# Altium schematic sheet 18-USB_HS.SchDoc
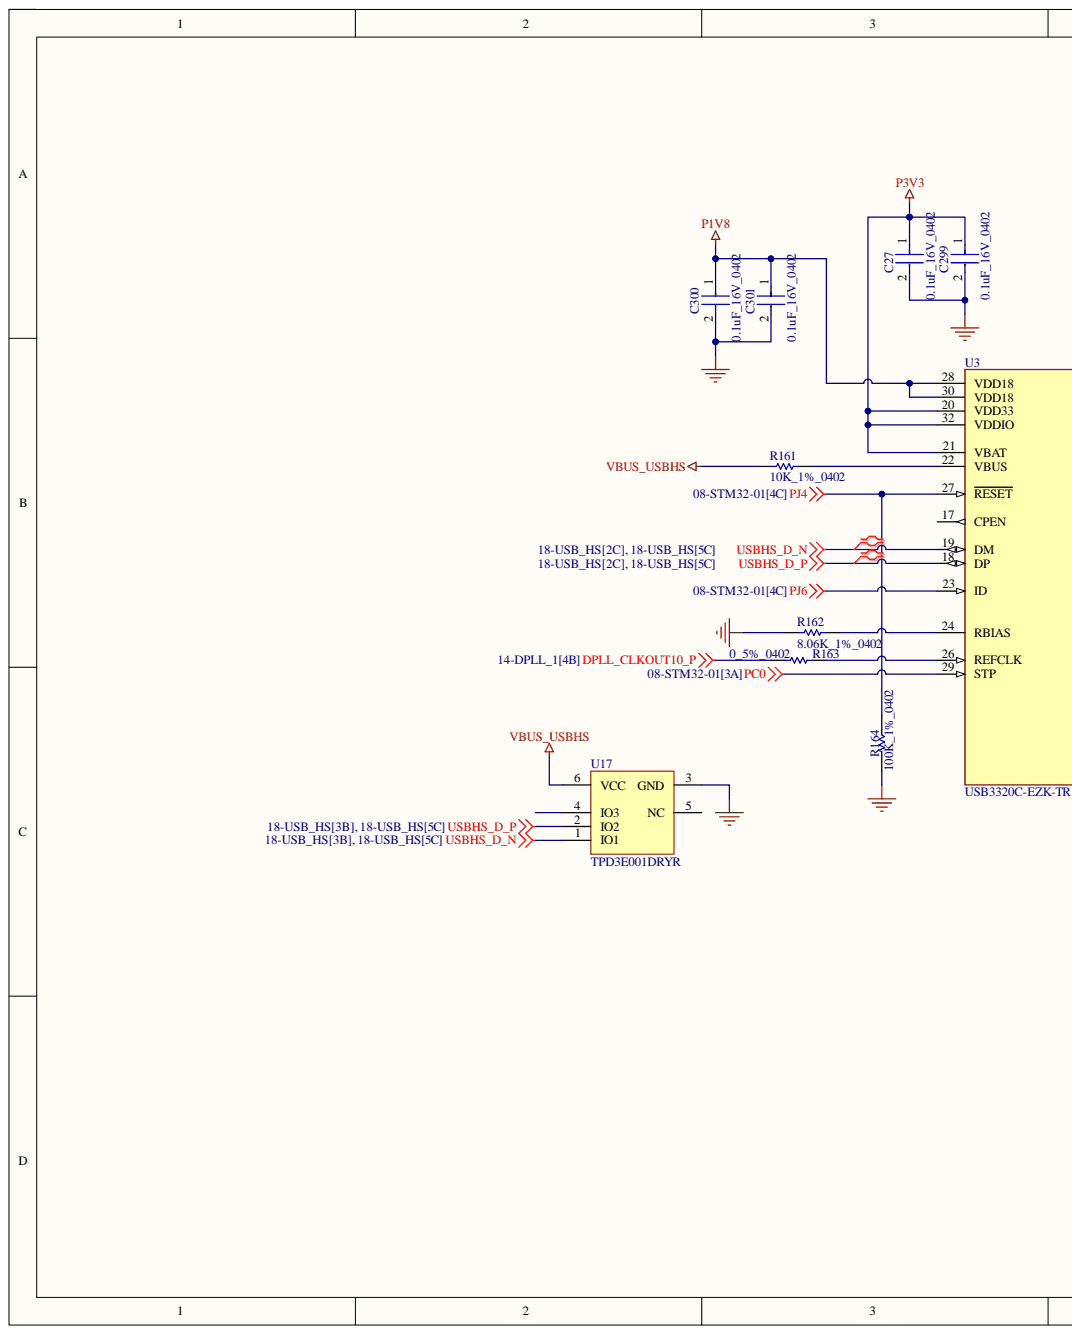
[diagram p0: 18-USB_HS.SchDoc, left]
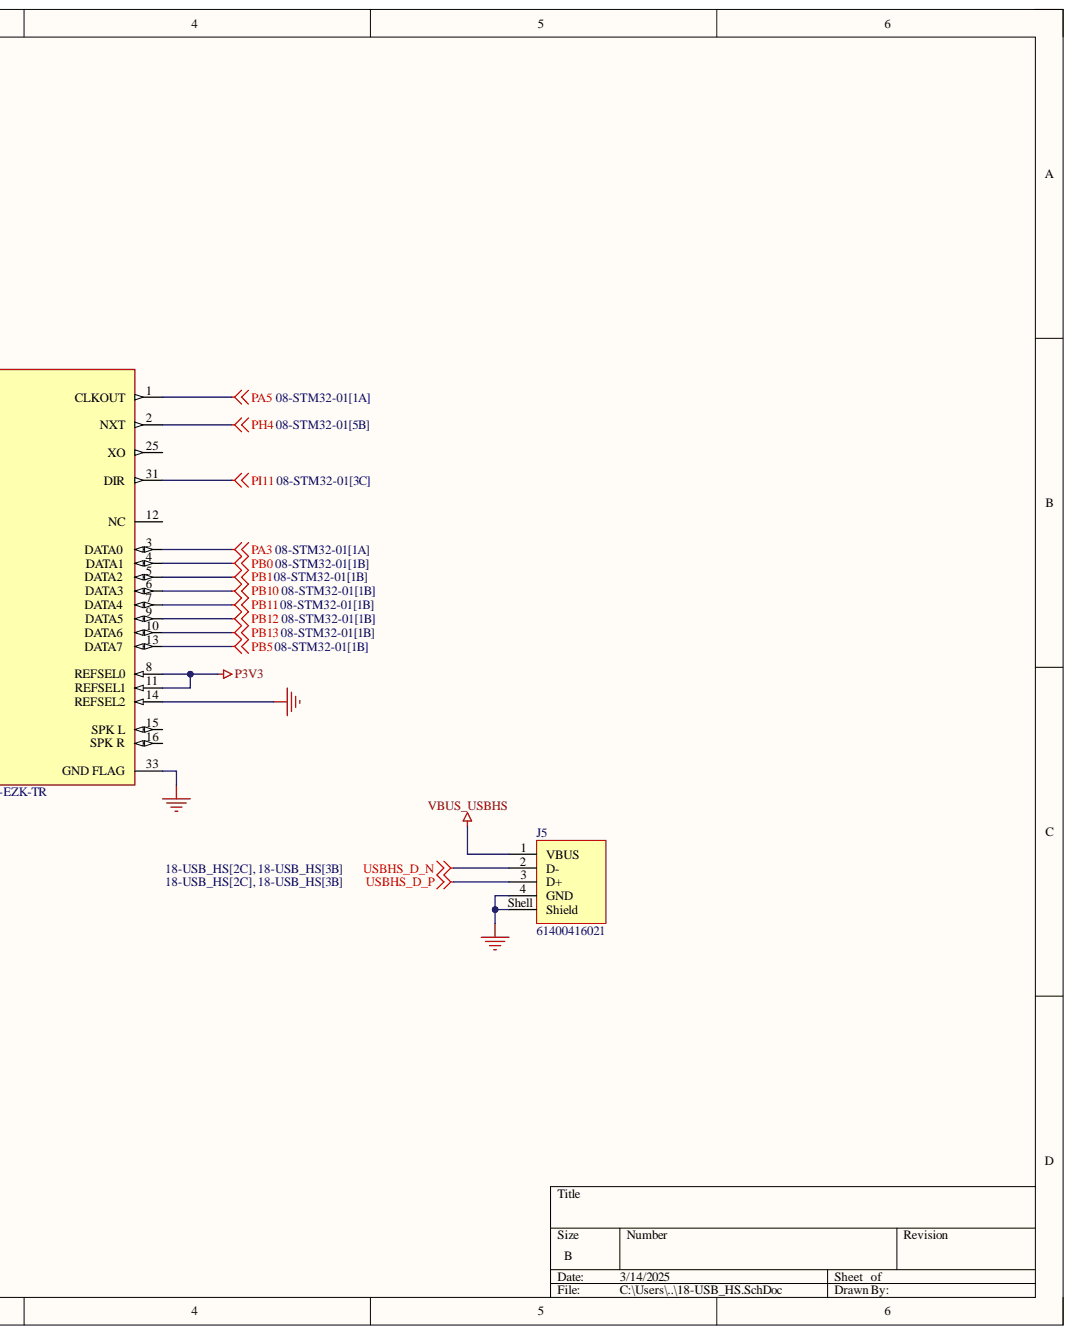
[diagram p1: 18-USB_HS.SchDoc, right]

## Parts
C27  0.1uF_16V_0402  [FP-C0402-BB-MFG]
C299  0.1uF_16V_0402  [FP-C0402-BB-MFG]
C300  0.1uF_16V_0402  [FP-C0402-BB-MFG]
C301  0.1uF_16V_0402  [FP-C0402-BB-MFG]
J5  61400416021  [61400416021]
R161  10K_1%_0402  [RESC1005X40X25NL10T10]
R162  8.06K_1%_0402  [RESC1005X40X25LL05T05]
R163  0_5%_0402  [RESC1005X40X25LL10T10]
R164  100K_1%_0402  [RESC1005X40X25ML05T10]
U3  USB3320C-EZK-TR  [FP-32QFN-3304-5X5B-MFG]
U17  TPD3E001DRYR  [DRY0006A]

## Nets
DPLL_CLKOUT10_P: R163.1
GND: C27.2 C299.2 C300.2 C301.2 J5.4(GND) J5.Shell(Shield) R162.1 R164.1 U3.14(REFSEL2) U3.33(GND_FLAG) U17.3(GND)
P1V8: C300.1 C301.1 U3.28(VDD18) U3.30(VDD18)
P3V3: C27.1 C299.1 U3.8(REFSEL0) U3.11(REFSEL1) U3.20(VDD33) U3.21(VBAT) U3.32(VDDIO)
PA3: U3.3(DATA0)
PA5: U3.1(CLKOUT)
PB0: U3.4(DATA1)
PB1: U3.5(DATA2)
PB5: U3.13(DATA7)
PB10: U3.6(DATA3)
PB11: U3.7(DATA4)
PB12: U3.9(DATA5)
PB13: U3.10(DATA6)
PC0: U3.29(STP)
PH4: U3.2(NXT)
PI11: U3.31(DIR)
PJ4: R164.2 U3.27(RESET)
PJ6: U3.23(ID)
USBHS_D_N: J5.2(D-) U3.19(DM) U17.1(IO1)
USBHS_D_P: J5.3(D+) U3.18(DP) U17.2(IO2)
VBUS_USBHS: J5.1(VBUS) R161.2 U17.6(VCC)
NetR161_1: R161.1 U3.22(VBUS)
NetR162_2: R162.2 U3.24(RBIAS)
NetR163_2: R163.2 U3.26(REFCLK)

Not connected: U3 12(NC) 15(SPK_L) 16(SPK_R) 17(CPEN) 25(XO); U17 4(IO3) 5(NC)

## Library data of the parts
C27 C299-C301 = CMP-1034-02068-3: CAP CER 0.1UF 16V 5% X7R 0402; Manufacturer: Kemet; Manufacturer Part Number: C0402C104J4RACTU; Capacitance: 0.10 μF; Material: Ceramic; Temperature Coefficient: 15%; Tolerance: 0.05; Value: 0.10 μF; Automotive: No; Category: Cap; Component Kind: Standard; ComponentLink2Description: Datasheet; ComponentLink2URL: https://content.kemet.com/datasheets/KEM_C1002_X7R_SMD.pdf; Device Class L1: Passive Components; Device Class L2: Capacitors; Device Class L3: Ceramic Capacitors; Digikey Description: CAP CER 0.1UF 16V 5% X7R 0402; Height: 0.55mm; Ipc Land Pattern Name: CAPC10050X50; Lead Free: Yes; Library Name: Altium Content Vault; Mouser Description: CAP CER 0.1UF 16V X7R 0402; Octopart: https://octopart.com/c0402c104j4ractu-kemet-12573893; Package: C0402-BB; RoHS: TRUE; Temperature: -55°C to +125°C; Temperature Characteristic: X7R; Temperature Range High: +125°C; Temperature Range Low: -55°C; Voltage Rating: 16 V
J5 = CMP-1502-03227-2: NexarPartId: 21041530; Connector Type: USB; Contact Resistance: 20mΩ; Current Rating: 1.5A; Gender: Receptacle; Insulation Resistance: 1GΩ; Number of Contacts: 4; Number of Ports: 1; Operating Supply Voltage: 30V; USB Standard: USB 2.0; Color: White; Component Kind: Standard; Contact Material: Copper; Contact Plating: Gold; Flammability Rating: UL94 V-0; Insulation Material: PBT; Lead Free: Lead Free; Length: 15.8mm; Library Name: Altium Content Vault; Max Operating Temperature: 105°C; Min Operating Temperature: -40°C; Mount: Horizontal, Through Hole; Number of Pins: 4; Number of Positions: 4; Orientation: Horizontal; REACH SVHC: Unknown; RoHS: Compliant; Termination: Solder; Voltage Rating: 30V; Voltage Rating (DC): 30V; Width: 14.4mm
R161 = CMP-2002-04694-1: Manufacturer: Bourns; Part Number: CR0402-FX-1002GLF; Component Kind: Standard; Library Name: Altium Content Vault
R162 = CMP-1011-00612-2: Manufacturer: Panasonic; Part Number: ERJ-2RKF8061X; Component Kind: Standard; Library Name: Altium Content Vault
R163 = CMP-2002-04569-1: Manufacturer: KOA Speer; Part Number: RK73Z1ETTP; Component Kind: Standard; Library Name: Altium Content Vault
R164 = CMP-2002-07852-1: Manufacturer: Yageo; Part Number: RC0402FR-13100KL; Component Kind: Standard; Library Name: Altium Content Vault
U3 = CMP-0186-00336-2: IC TRANSCEIVER HALF 1/1 32QFN; Manufacturer: Microchip; Interface: UART,USB; Max Supply Voltage: 5.5V; Min Supply Voltage: 1.6V; Nominal Supply Current: 0.7-29400uA; Number Of Ports: 1; Standoff Height: 0mm; Usb Standard: USB 2.0; Ambient Temperature Range High: +85°C; Ambient Temperature Range Low: -40°C; Automotive: No; Category: IC; Component Kind: Standard; ComponentLink2Description: Datasheet; ComponentLink2URL: http://ww1.microchip.com/downloads/en/DeviceDoc/00001792E.pdf; Device Class L1: Integrated Circuits (ICs); Device Class L2: Interface ICs; Device Class L3: USB Interface ICs; Digikey Description: IC TRANSCEIVER HALF 1/1 32QFN; Height: 1mm; Ipc Land Pattern Name: QFN50P500X500X85-32; Lead Free: Yes; Library Name: Altium Content Vault; Manufacturer Part Number: USB3320C-EZK-TR; Mouser Description: Microchip USB3320C-EZK-TR, USB Transceiver, USB 2.0, 1.8 V, 3.3 V, 32-Pin QFN; Octopart: https://octopart.com/usb3320c-ezk-tr-microchip-24995468; Package: 32QFN-3304-5X5B; RoHS: TRUE; Temperature: -40°C to +85°C; Temperature Range High: +85°C; Temperature Range Low: -40°C
U17 = CMP-0326-00032-3: Low-Capacitance + / - 15 kV ESD-Protection Array for High-Speed Data Interfaces, 3 Channels, -40 to +85 degC, 6-pin SON (DRY), Green (RoHS & no Sb/Br); Manufacturer: Texas Instruments; Breakdown Voltage(Min)(V): 11; IEC 61000-4-2 Air-Gap(+/- kV): 15; IEC 61000-4-2 Contact(+/- kV): 8; IO Capacitance(Typ)(pF): 1.5; IO Leakage Current(nA): Jan-00; SubFamily: ESD Solution; USB High Speed: TRUE; Component Kind: Standard; ComponentLink1Description: Manufacturer URL; ComponentLink1URL: http://www.ti.com/; ComponentLink2Description: Datasheet; ComponentLink2URL: http://www.ti.com/general/docs/lit/getliterature.tsp?genericPartNumber=TPD3E001&fileType=pdf; ComponentLink3Description: URL Link1; ComponentLink3URL: SamplesURL:http://www.ti.com/product/tpd3e001#samples; DatasheetVersion: SLLS683D; Eco-Plan: Green (RoHS & no Sb/Br); Library Name: Altium Content Vault; Mounting Technology: Surface Mount; PackageDescription: 6-Pin Plastic Small Outline No-Lead, 1 x 1.45 x 0.6 mm Body, 0.5 mm Pitch; PackageReference: DRY0006A; PackageVersion: revF, Dec-2011; PartNumber: TPD3E001DRYR
